# Altium schematic sheet VEB_NL_9.09.02.SchDoc(VEB_NL_9.09.02)
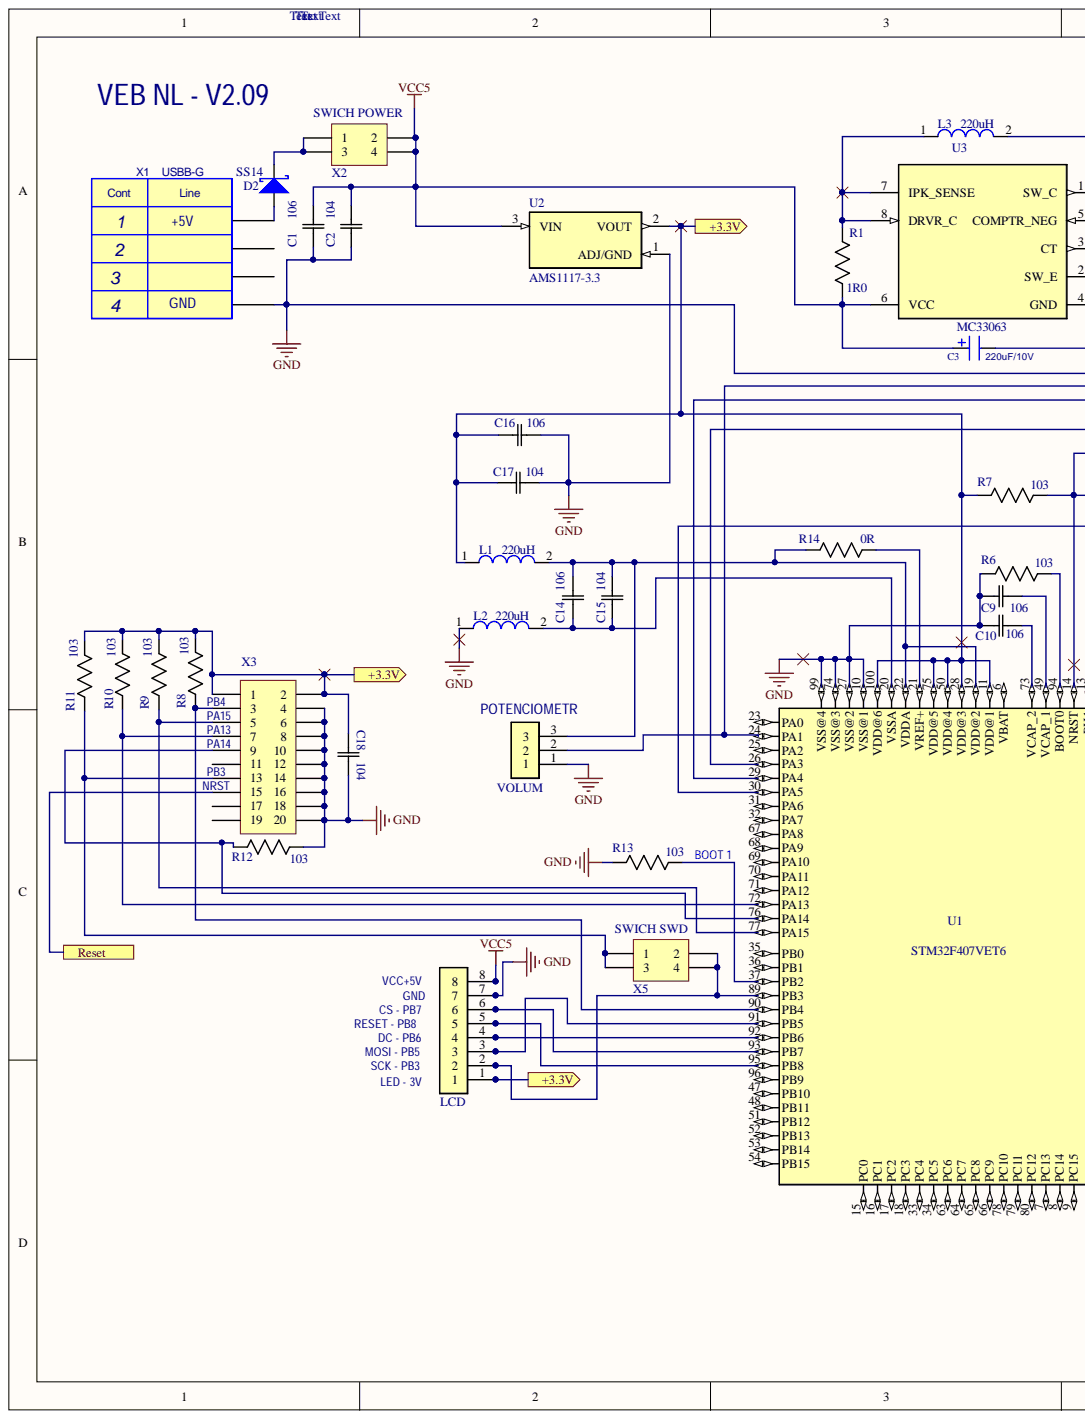
[diagram p0: VEB_NL_9.09.02.SchDoc, left]
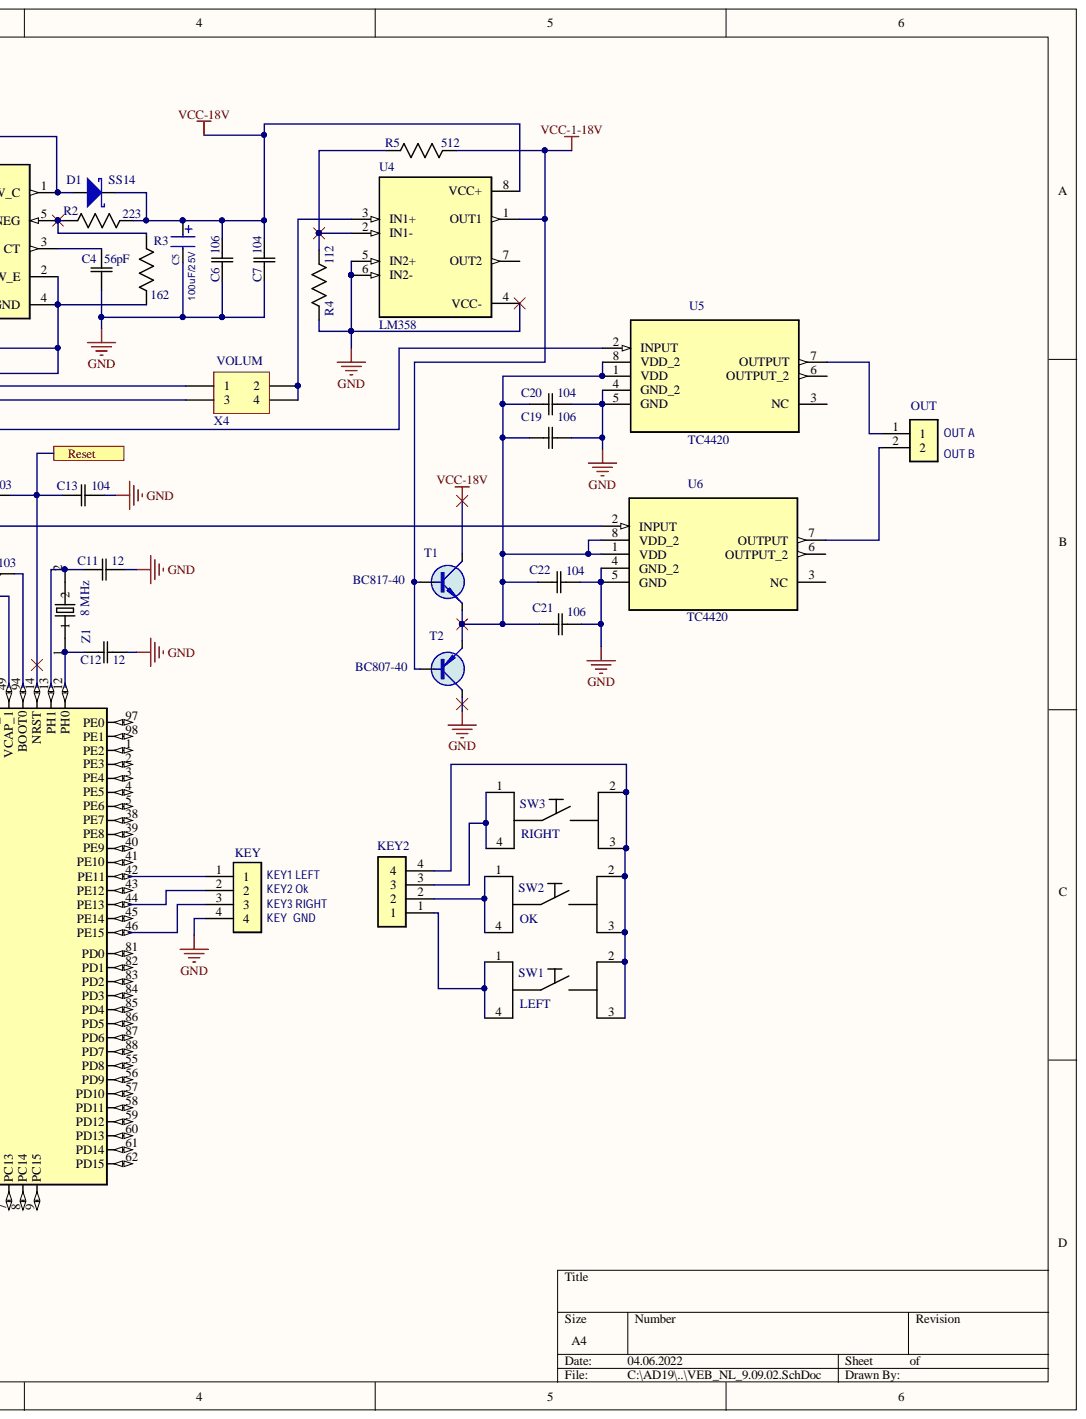
[diagram p1: VEB_NL_9.09.02.SchDoc, right]

## Parts
C1  106  [CAPC3216X94N]
C2  104  [CAPC3216X94N]
C3  220uF/10V  [Cap 0810]
C4  56pF  [CAPC3216X94N]
C5  100uF/25V  [Cap 0810]
C6  106  [CAPC3216X94N]
C7  104  [CAPC3216X94N]
C9  106  [CAPC3216X94N]
C10  106  [CAPC3216X94N]
C11  12  [CAPC3216X94N]
C12  12  [CAPC3216X94N]
C13  104  [CAPC3216X94N]
C14  106  [CAPC3216X94N]
C15  104  [CAPC3216X94N]
C16  106  [CAPC3216X94N]
C17  104  [CAPC3216X94N]
C18  104  [CAPC3216X94N]
C19  106  [CAPC3216X94N]
C20  104  [CAPC3216X94N]
C21  106  [CAPC3216X94N]
C22  104  [CAPC3216X94N]
D1  SS14  [DO-214AC]
D2  SS14  [DO-214AC]
KEY  282837-4  [TE_282837-4]  (value not drawn)
KEY2  282837-4  [TE_282837-4]  (value not drawn)
L1  220uH  [INDP5858X480N]
L2  220uH  [INDP5858X480N]
L3  220uH  [INDP5858X480N]
LCD  282837-4  [TE_282837-4]  (value not drawn)
OUT  282837-4  [TE_282837-4]  (value not drawn)
R1  1R0  [RESC3216X71N]
R2  223  [RESC3216X71N]
R3  162  [RESC3216X71N]
R4  112  [RESC3216X71N]
R5  512  [RESC3216X71N]
R6  103  [RESC3216X71N]
R7  103  [RESC3216X71N]
R8  103  [RESC3216X71N]
R9  103  [RESC3216X71N]
R10  103  [RESC3216X71N]
R11  103  [RESC3216X71N]
R12  103  [RESC3216X71N]
R13  103  [RESC3216X71N]
R14  0R  [RESC3216X71N]
SW1  LEFT  [SWITCH_1241.1607.23]
SW2  OK  [SWITCH_1241.1607.23]
SW3  RIGHT  [SWITCH_1241.1607.23]
T1  BC817  value BC817-40  [SOT23]
T2  BC807  value BC807-40  [SOT23]
U1  STM32F407VET6  [LQFP100-0.5-13.8X13.8MM]
U2  AMS1117-3.3  [SOT229P700X180-4N]
U3  MC33063  [SOIC127P600X175-8N]
U4  LM358  [SOIC127P600X175-8N]
U5  TC4420  [SOIC127P600X175-8N]
U6  TC4420  [SOIC127P600X175-8N]
VOLUM  282837-4  [TE_282837-4]  (value not drawn)
X1  USBB-G  [USBB-G]
X2  SWICH POWER  [PLD-4-2]
X3  PLD2-20  [PLD-20-2]  (value not drawn)
X4  VOLUM  [PLD-4-2]
X5  SWICH SWD  [PLD-4-2]
Z1  8 MHz  [XTAL1170X500X450]

## Ports
+3.3V, Reset

## Nets
GND: C1.1 C2.1 C3.2 C4.1 C5.2 C6.1 C7.1 C9.1 C10.1 C11.2 C12.2 C13.2 C16.2 C17.2 C18.2 C19.2 C20.2 C21.2 C22.2 KEY.4 L2.1 LCD.7 R3.1 R4.2 R6.2 R12.1 R13.2 T2.3 U1.10(VSS@1) U1.27(VSS@2) U1.74(VSS@3) U1.99(VSS@4) U2.1 U3.2(SW_E) U3.4(GND) U4.4(VCC-) U4.5(IN2+) U4.6(IN2-) U5.4(GND_2) U5.5(GND) U6.4(GND_2) U6.5(GND) VOLUM.1 X1.4 X3.4 X3.6 X3.8 X3.10 X3.12 X3.14 X3.16 X3.18 X3.20
VCC5: C1.2 C2.2 C3.1 LCD.8 R1.1 U2.3 U3.6(VCC) X2.2 X2.4
VCC-1-18V: R5.1 T1.1 T2.1 U4.1(OUT1)
VCC-18V: C5.1 C6.2 C7.2 D1.2 R2.1 T1.3 U4.8(VCC+)
+3.3V: C16.1 C17.1 C18.1 L1.1 LCD.1 R7.2 R8.1 R9.1 R10.1 R11.1 U1.11(VDD@1) U1.28(VDD@3) U1.50(VDD@4) U1.75(VDD@5) U1.100(VDD@6) U2.2 X3.1 X3.2 ; port +3.3V
Reset: C13.1 R7.1 U1.14(NRST) X3.15 ; port Reset
NetC4_2: C4.2 U3.3(CT)
NetC9_2: C9.2 U1.49(VCAP_1)
NetC10_2: C10.2 U1.73(VCAP_2)
NetC11_1: C11.1 U1.13(PH1) Z1.2
NetC12_1: C12.1 U1.12(PH0) Z1.1
NetC14_1: C14.1 C15.1 L2.2 U1.20(VSSA)
NetC14_2: C14.2 C15.2 L1.2 R14.2 U1.19(VDD@2) U1.22(VDDA) VOLUM.3
NetC19_1: C19.1 C20.1 C21.1 C22.1 T1.2 T2.2 U5.1(VDD) U5.8(VDD_2) U6.1(VDD) U6.8(VDD_2)
NetD1_1: D1.1 L3.2 U3.1(SW_C)
NetD2_1: D2.1 X1.1
NetD2_2: D2.2 X2.1 X2.3
NetKEY2_1: KEY2.1 SW1.1 SW1.4
NetKEY2_2: KEY2.2 SW2.1 SW2.4
NetKEY2_3: KEY2.3 SW3.1 SW3.4
NetKEY2_4: KEY2.4 SW1.2 SW1.3 SW2.2 SW2.3 SW3.2 SW3.3
NetKEY_1: KEY.1 U1.42(PE11)
NetKEY_2: KEY.2 U1.44(PE13)
NetKEY_3: KEY.3 U1.46(PE15)
NetL3_1: L3.1 R1.2 U3.7(IPK_SENSE) U3.8(DRVR_C)
NetLCD_2: LCD.2 U1.89(PB3) X5.2 X5.4
NetLCD_3: LCD.3 U1.91(PB5)
NetLCD_4: LCD.4 U1.92(PB6)
NetLCD_5: LCD.5 U1.95(PB8)
NetLCD_6: LCD.6 U1.93(PB7)
NetOUT_1: OUT.1 U5.7(OUTPUT)
NetOUT_2: OUT.2 U6.7(OUTPUT)
NetR2_2: R2.2 R3.2 U3.5(COMPTR_NEG)
NetR4_1: R4.1 R5.2 U4.2(IN1-)
NetR6_1: R6.1 U1.94(BOOT0)
NetR8_2: R8.2 U1.90(PB4) X3.3
NetR9_2: R9.2 U1.77(PA15) X3.5
NetR10_2: R10.2 U1.72(PA13) X3.7
NetR11_2: R11.2 X3.13 X5.1 X5.3
NetR12_2: R12.2 U1.76(PA14) X3.9
NetR13_1: R13.1 U1.37(PB2)
NetR14_1: R14.1 U1.21(VREF+)
NetU1_24: U1.24(PA1) VOLUM.2 X4.1
NetU1_26: U1.26(PA3) U5.2(INPUT)
NetU1_29: U1.29(PA4) X4.3
NetU1_30: U1.30(PA5) U6.2(INPUT)
NetU4_3: U4.3(IN1+) X4.2 X4.4

Not connected: U1 1(PE2) 2(PE3) 3(PE4) 4(PE5) 5(PE6) 6(VBAT) 7(PC13) 8(PC14) 9(PC15) 15(PC0) 16(PC1) 17(PC2) 18(PC3) 23(PA0) 25(PA2) 31(PA6) 32(PA7) 33(PC4) 34(PC5) 35(PB0) 36(PB1) 38(PE7) 39(PE8) 40(PE9) 41(PE10) 43(PE12) 45(PE14) 47(PB10) 48(PB11) 51(PB12) 52(PB13) 53(PB14) 54(PB15) 55(PD8) 56(PD9) 57(PD10) 58(PD11) 59(PD12) 60(PD13) 61(PD14) 62(PD15) 63(PC6) 64(PC7) 65(PC8) 66(PC9) 67(PA8) 68(PA9) 69(PA10) 70(PA11) 71(PA12) 78(PC10) 79(PC11) 80(PC12) 81(PD0) 82(PD1) 83(PD2) 84(PD3) 85(PD4) 86(PD5) 87(PD6) 88(PD7) 96(PB9) 97(PE0) 98(PE1); U4 7(OUT2); U5 3(NC) 6(OUTPUT_2); U6 3(NC) 6(OUTPUT_2); X1 2 3; X3 11 17 19

## Library data of the parts
C1 C2 C4 C6 C7 C9-C18 C20-C22 = 12061C103KAT4A: 1206 10nF 100 V В± 10% Р”РѕРїСѓСЃРє X7R SMT РњРЅРѕРіРѕСЃР»РѕР№РЅС‹Р№ РєРµСЂР°РјРёС‡РµСЃРєРёР№ РєРѕРЅРґРµРЅСЃР°С‚РѕСЂ; MF: AVX; SnapEDA_Link: https://www.snapeda.com/parts/12061C103KAT4A/AVX/view-part/?ref=snap; Price: 0.05 USD; Package: 1206 AVX; MP: 12061C103KAT4A; Library Name: C12061.IntLib; Component Kind: Standard; Availability: Bad
C3 C5 = CAP0810Alu: Capacitor; Library Name: AX_Altium_v2.3.IntLib; Component Kind: Standard
  C3: Value: 220uF/10V
  C5: Value: 100uF/25V
C19 = 12061C103: 1206 10nF 100 V В± 10%; MP: 12061C103KAT4A; MF: AVX; SnapEDA_Link: https://www.snapeda.com/parts/12061C103KAT4A/AVX/view-part/?ref=snap; Price: 0.05 USD; Package: 1206 AVX; Component Kind: Standard; Availability: Bad; Library Name: VEB_NL_9.09.SCHLIB
D1 D2 = DS_DO-214: Schottky Diode; Library Name: AX_Altium_v2.3.IntLib; Component Kind: Standard
KEY KEY2 LCD OUT VOLUM = 282837-4: TERMI-BLOK PCB MOUNT 4P; MF: TE Connectivity; SnapEDA_Link: https://www.snapeda.com/parts/282837-4/TE%20Connectivity%20AMP%20Connectors/view-part/?ref=snap; Price: None; Package: None; MP: 282837-4; Link: http://www.te.com/usa-en/product-282837-4.html; EU_RoHS_Compliance: Compliant with Exemptions; Component Kind: Standard; Availability: Unavailable
  KEY KEY2: Library Name: PIN-4.IntLib
  LCD: Library Name: PIN-8.IntLib
  OUT: Library Name: PIN-02.IntLib
  VOLUM: Library Name: PIN-03.IntLib
L1-L3 = SDR0604-221KL: РњРѕС‰РЅРѕСЃС‚СЊ РёРЅРґСѓРєС‚РѕСЂР° Wirewound 220uH 10% 1KHz 20Q-С„Р°РєС‚РѕСЂ Р¤РµСЂСЂРёС‚РѕРІС‹Р№ 350mA 1.57Ohm DCR T / R; MF: Bourns; SnapEDA_Link: https://www.snapeda.com/parts/SDR0604-221KL/Bourns/view-part/?ref=snap; Price: 0.27 USD; Package: Nonstandard Bourns; MP: SDR0604-221KL; Library Name: 220uH-SDR0604.IntLib; Component Kind: Standard; Availability: Good
R1-R13 = RC1206JR-07470RL: Res РўРѕР»СЃС‚Р°СЏ РїР»РµРЅРєР° 3,2 x 1,6 РјРј 470 РћРј 5% 0,25 Р’С‚ _1/4 Р’С‚_ 100 ppm / C Р›РёС‚Р°СЏ SMD-Р±СѓРјР°РіР° T / R; MF: Yageo; SnapEDA_Link: https://www.snapeda.com/parts/RC1206JR-07470RL/Yageo/view-part/?ref=snap; Price: 0.02 USD; Package: 1206 Yageo; MP: RC1206JR-07470RL; Library Name: R1206.IntLib; Component Kind: Standard; Availability: Good
R14 = Res 1206: Res 1206 3,2 x 1,6 SMD; MP: RC1206JR-07470RL; MF: Yageo; Package: 1206 Yageo; Component Kind: Standard; Availability: Good; Library Name: VEB_NL_5.09.SCHLIB
SW1-SW3 = 1241.1607.23: Switch Tactile NO SPST РљСЂСѓРіР»Р°СЏ РєРЅРѕРїРєР° J-Lead 0.05A 30VAC 42VDC 1000000Cycles 1.8N SMD T / R; MF: Schurter; SnapEDA_Link: https://www.snapeda.com/parts/1241.1607.23/Schurter/view-part/?ref=snap; Price: 1.96 USD; Package: None; MP: 1241.1607.23; Component Kind: Standard; Availability: Good; Library Name: BUT_12x12.IntLib
T1 = BC817-40: SOT23    45V 0.5A 100MHz 10pF (10V); Part Number: BC817-25LT3G; Manufacturer: ON Semiconductor; Vce: 45; TYPE: Transistors; Power: 0.225W; h21e: 250-600; Complementary: BC807-40; Technology: npn; Supplier Part Number 1: 863-BC817-25LT3G; Supplier 1: Mouser; Simulation: BC817-40; Signal Integrity: BJT; RoHS: Y; Published: 28.09.2019; Price: 0.3; Package: SOT23; Mount Type: SMT; Library Name: BJT.IntLib; Ic: 0.5A; Ft: 100MHz; ComponentLink1URL: https://www.mouser.bg/datasheet/2/308/BC817-16LT1-D-104560.pdf; ComponentLink1Description: Datasheet Link; Component Kind: Standard; Publisher: Hefest
T2 = BC807-40: SOT23    45V 0.5A 100MHz 10pF (10V); Part Number: BC807-40LT1G; Manufacturer: ON Semiconductor; TYPE: Transistors; Power: 0.225W; h21e: 250-600; Complementary: BC817-40; Vce: 45V; Technology: pnp; Supplier Part Number 1: 863-BC807-40LT1G; Supplier 1: Mouser; Simulation: BC807-40; Signal Integrity: BJT; RoHS: Y; Published: 28.09.2019; Price: 0.28; Package: SOT23; Mount Type: SMT; Library Name: BJT.IntLib; Ic: 0.5A; Ft: 100MHz; ComponentLink1URL: https://www.mouser.bg/datasheet/2/308/BC807-16LT1-D-1360202.pdf; ComponentLink1Description: Datasheet Link; Component Kind: Standard; Publisher: Hefest
U1 = MCU-STM32F407VET6(LQFP100): STM32 Series 32-bit 512 kB Flash 192 kB RAM РњРёРєСЂРѕРєРѕРЅС‚СЂРѕР»Р»РµСЂ РЅР° Р±Р°Р·Рµ ARM - LQFP-100; MF: STMicroelectronics; SnapEDA_Link: https://www.snapeda.com/parts/STM32F407VET6/STMicroelectronics/view-part/?ref=snap; Price: 9.04 USD; Package: LQFP-100 STMicroelectronics; MP: STM32F407VET6; Library Name: STM32F407VET6.IntLib; Component Kind: Standard; Availability: Good
U2 = AMS1117-3.3: MF: NEC; SnapEDA_Link: https://www.snapeda.com/parts/AMS1117-3.3/NEC/view-part/?ref=snap; Price: None; Package: None; MP: AMS1117-3.3; Library Name: AMS1117-3.3.IntLib; Component Kind: Standard; Availability: Unavailable
U3 = MC33063ADG: MC33063A Series 40V 1.5 A Р РµРіСѓР»СЏС‚РѕСЂ РїРµСЂРµРєР»СЋС‡РµРЅРёСЏ СЃ С€Р°РіРѕРј 100 РєР“С† СЃ С€Р°РіРѕРј / РІРЅРёР· / РёРЅРІРµСЂС‚РёСЂРѕРІР°РЅРёРµРј; MF: ON Semiconductor; SnapEDA_Link: https://www.snapeda.com/parts/MC33063ADG/ON%2520Semiconductor/view-part/?ref=snap; Package: SOIC-8 ON Semiconductor; MP: MC33063ADG; Library Name: MC33063ADG.IntLib; Component Kind: Standard
U4 = LM358ADT: LM358 Series 30 V 1,1 РњР“С† СЃ РЅРёР·РєРёРј СЌРЅРµСЂРіРѕРїРѕС‚СЂРµР±Р»РµРЅРёРµРј СЃ РґРІРѕР№РЅС‹Рј СЂР°Р±РѕС‡РёРј СѓСЃРёР»РёС‚РµР»РµРј - SOIC-8; MF: STMicroelectronics; SnapEDA_Link: https://www.snapeda.com/parts/LM358ADT/STMicroelectronics/view-part/?ref=snap; Price: 0.16 USD; Package: SOIC-8 STMicroelectronics; MP: LM358ADT; Library Name: LM358ADT.IntLib; Component Kind: Standard; Availability: Good
U5 U6 = TC4420EOA: TC4420 Series 6 A 18 Vmax 2,5 РћРј СЃ РЅРёР·РєРёРј СЃРѕРїСЂРѕС‚РёРІР»РµРЅРёРµРј Р±РµР· РёРЅРІРµСЂС‚РѕСЂР° MOSFET - SOIC-8; MF: Microchip; SnapEDA_Link: https://www.snapeda.com/parts/TC4420EOA/Microchip/view-part/?ref=snap; Price: 1.15 USD; Package: SOIC-8 Microchip; MP: TC4420EOA; Library Name: TC4420EOA.IntLib; Component Kind: Standard; Availability: Good
X2 X4 X5 = PLD2-4: Header, 2-Pin, Dual row; Library Name: AX_Altium_v2.3.IntLib; LatestRevisionNote: Re-released for DXP Platform.; LatestRevisionDate: 17-Jul-2002; Component Kind: Standard; Publisher: Altium Limited
X3 = PLD2-20: Header, 10-Pin, Dual row; Library Name: AX_Altium_v2.3.IntLib; LatestRevisionNote: Re-released for DXP Platform.; LatestRevisionDate: 17-Jul-2002; Component Kind: Standard; Publisher: Altium Limited
Z1 = FOXSDLF/080-20: Crystal 8MHz? 30ppm _Tol_? 50ppm _СЃС‚Р°Р±РёР»СЊРЅРѕСЃС‚СЊ_ 20pF Р¤РћРќР” 80Ohm 2-РєРѕРЅС‚Р°РєС‚РЅС‹Р№ HC-49 / SDLF SMD T / R; MF: Fox Electronics; SnapEDA_Link: https://www.snapeda.com/parts/FOXSDLF/080-20/Fox%2520Electronics/view-part/?ref=snap; Price: 0.23 USD; Package: HC49SD Fox Electronics; MP: FOXSDLF/080-20; Library Name: 8MHZ-HC49SD.IntLib; Component Kind: Standard; Availability: Good
X1 = USBB-G: Library Name: USB-B-G.IntLib; Description: USBB-G; Component Kind: Standard
